# Altium schematic sheet Motor_Driver.SchDoc
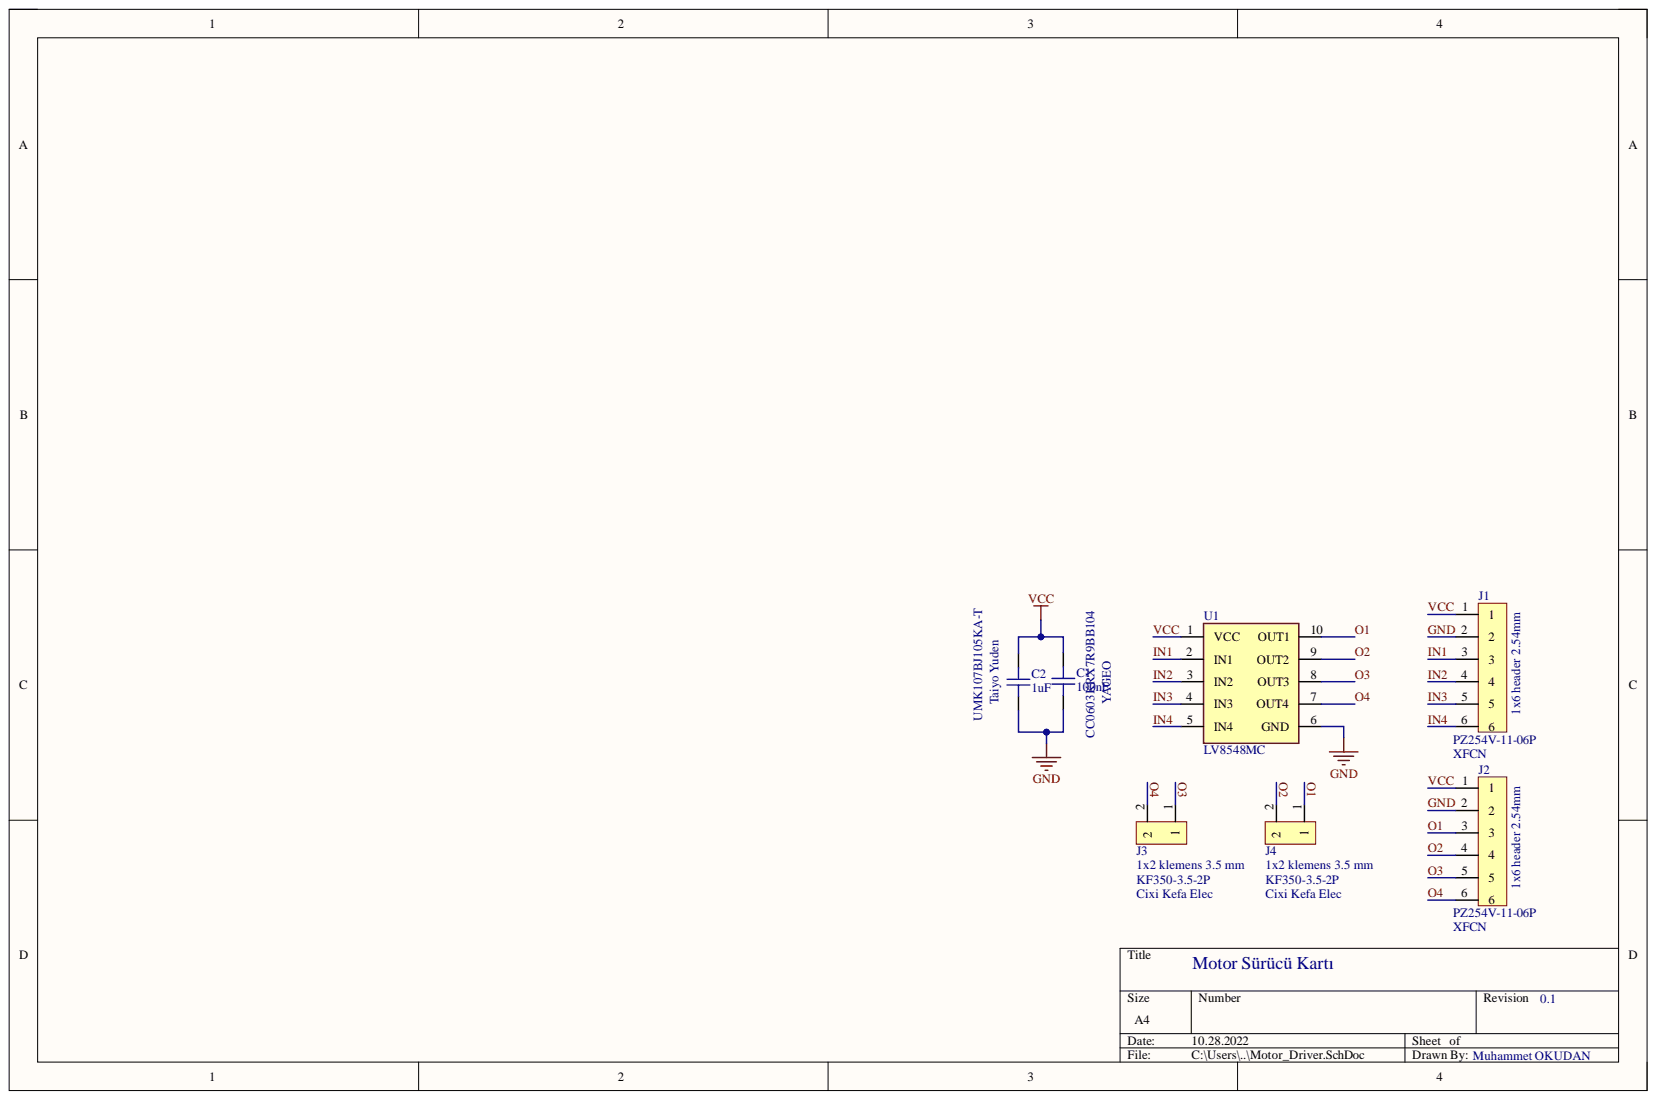
[diagram p0: Motor_Driver.SchDoc]

## Parts
C1  100nF  *Mfg Part#: CC0603KRX7R9BB104  [CAP 0603]
C2  1uF  *Mfg Part#: UMK107BJ105KA-T  Manufacturer: Taiyo Yuden  [CAP 0603]
J1  1x6 header 2.54mm  *Mfg Part#: PZ254V-11-06P  [1x6 2.54mm Header]
J2  1x6 header 2.54mm  *Mfg Part#: PZ254V-11-06P  Manufacturer: XFCN  [1x6 2.54mm Header]
J3  1x2 klemens 3.5 mm  [1x2 klemens 3.5mm]
J4  1x2 klemens 3.5 mm  [1x2 klemens 3.5mm]
U1  LV8548MC  [LV8548MC MOTOR DRIVER SOIC]

## Nets
GND: C1.1 C2.1 J1.2 J2.2 U1.6(GND)
IN1: J1.3 U1.2(IN1)
IN2: J1.4 U1.3(IN2)
IN3: J1.5 U1.4(IN3)
IN4: J1.6 U1.5(IN4)
O1: J2.3 J4.1 U1.10(OUT1)
O2: J2.4 J4.2 U1.9(OUT2)
O3: J2.5 J3.1 U1.8(OUT3)
O4: J2.6 J3.2 U1.7(OUT4)
VCC: C1.2 C2.2 J1.1 J2.1 U1.1(VCC)

## Library data of the parts
C1 = CAP 1206: Manufacturer: YAGEO; Component Kind: Standard; Library Name: Motor_Driver.SchLib
C2 = CAP 1206: Component Kind: Standard; Library Name: Motor_Driver.SchLib
J1 = 1x6 header 2.54mm: Manufacturer: XFCN; Component Kind: Standard; Library Name: Motor_Driver.SchLib
J3 J4 = 1x2 klemens 3.5 mm: *Mfg Part#: KF350-3.5-2P; Manufacturer: Cixi Kefa Elec; Component Kind: Standard; Library Name: Motor_Driver.SchLib
U1 = LV8548MC: IC-8548 MOTOR DRIVER PAR MFP10S; Component Kind: Standard; Library Name: Motor_Driver.SchLib
J2 = 1x6 header 2.54mm: Component Kind: Standard; Library Name: Motor_Driver.SchLib
